# Altium schematic sheet Connectors-and-Filters.schdoc(Connectors-and-Filters)
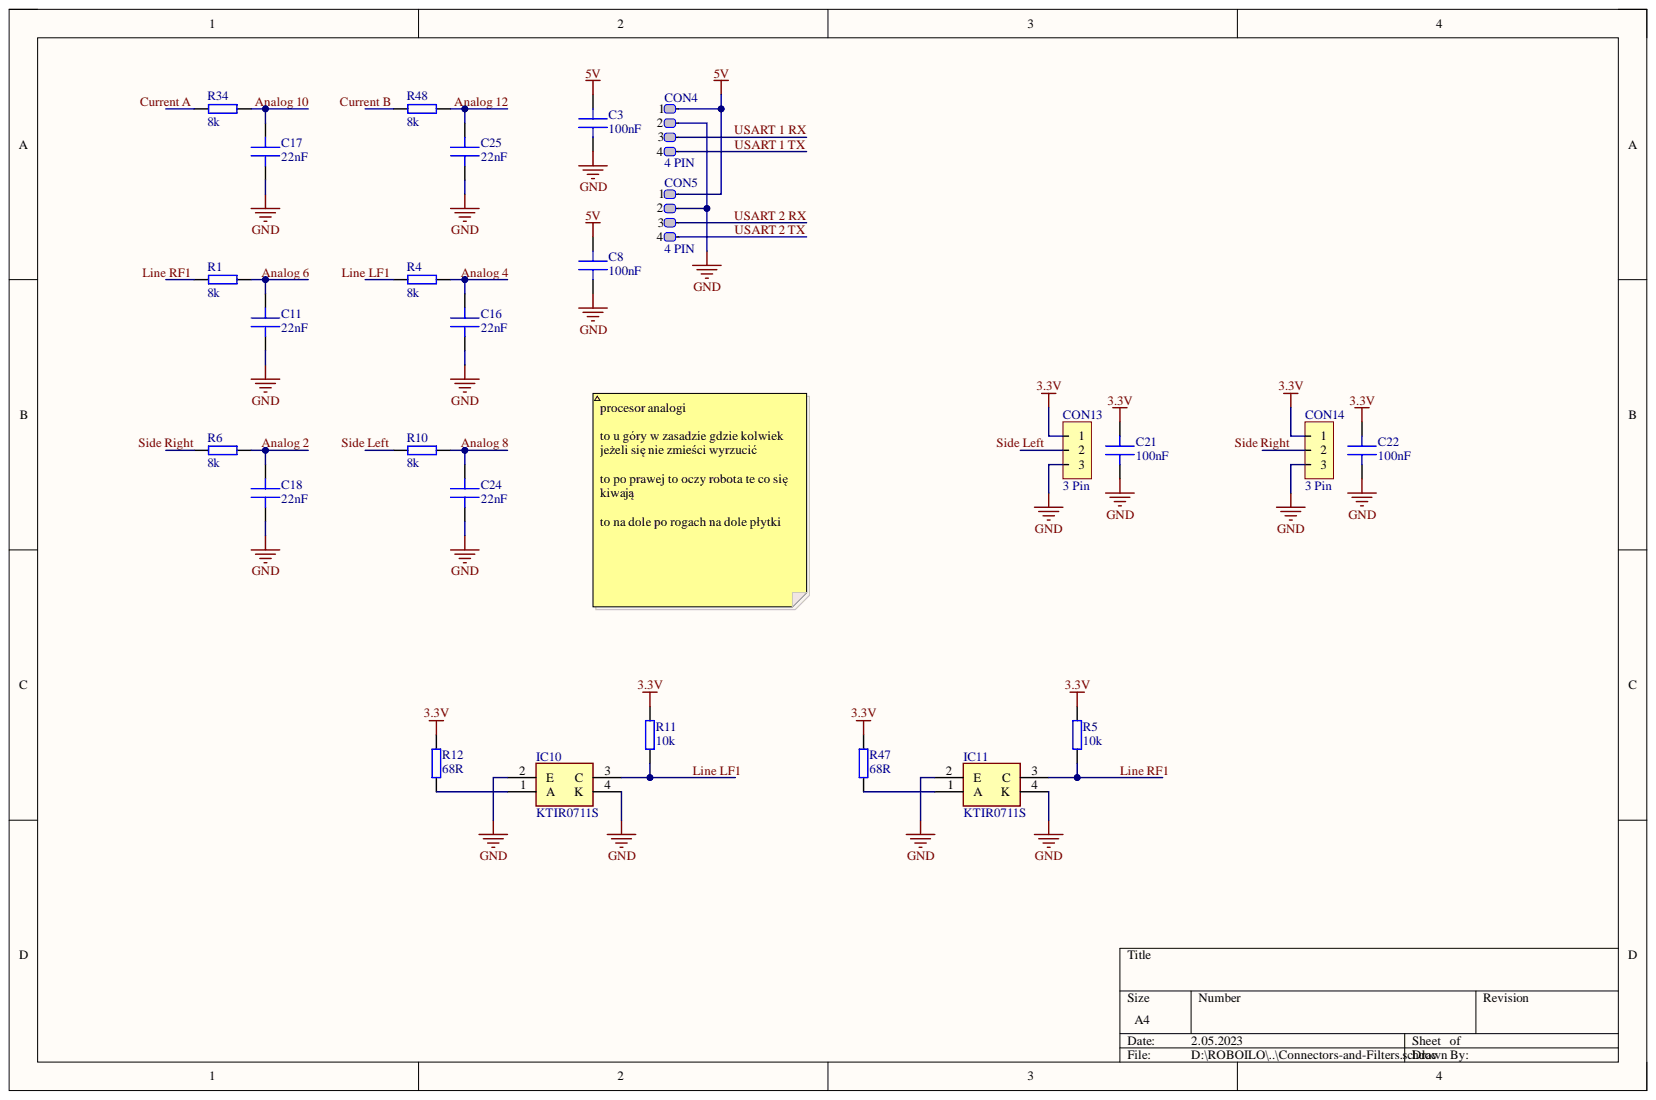
[diagram p0: Connectors-and-Filters.schdoc]

## Parts
C3  100nF  [C 0402]
C8  100nF  [C 0402]
C11  22nF  [C 0402]
C16  22nF  [C 0402]
C17  22nF  [C 0402]
C18  22nF  [C 0402]
C21  100nF  [C 0402]
C22  100nF  [C 0402]
C24  22nF  [C 0402]
C25  22nF  [C 0402]
CON4  4 PIN  [SM04B-SRSS-TB]
CON5  4 PIN  [SM04B-SRSS-TB]
CON13  3 Pin  [BM03B-SRSS-TB]
CON14  3 Pin  [BM03B-SRSS-TB]
IC10  KTIR0711S  [KTIR0711S]
IC11  KTIR0711S  [KTIR0711S]
R1  8k  [R 0402]
R4  8k  [R 0402]
R5  10k  [R 0402]
R6  8k  [R 0402]
R10  8k  [R 0402]
R11  10k  [R 0402]
R12  68R  [R 0402]
R34  8k  [R 0402]
R47  68R  [R 0402]
R48  8k  [R 0402]

## Nets
3.3V: C21.1 C22.1 CON13.1 CON14.1 R5.1 R11.1 R12.1 R47.1
5V: C3.1 C8.1 CON4.1 CON5.1
GND: C3.2 C8.2 C11.2 C16.2 C17.2 C18.2 C21.2 C22.2 C24.2 C25.2 CON4.2 CON5.2 CON13.3 CON14.3 IC10.2(E) IC10.4(K) IC11.2(E) IC11.4(K)
Analog 2: C18.1 R6.1
Analog 4: C16.1 R4.1
Analog 6: C11.1 R1.1
Analog 8: C24.1 R10.1
Analog 10: C17.1 R34.1
Analog 12: C25.1 R48.1
Current A: R34.2
Current B: R48.2
Line LF1: IC10.3(C) R4.2 R11.2
Line RF1: IC11.3(C) R1.2 R5.2
Side Left: CON13.2 R10.2
Side Right: CON14.2 R6.2
USART 1 RX: CON4.3
USART 1 TX: CON4.4
USART 2 RX: CON5.3
USART 2 TX: CON5.4
NetIC10_1: IC10.1(A) R12.2
NetIC11_1: IC11.1(A) R47.2

## Library data of the parts
C3 C8 C11 C16-C18 C21 C22 C24 C25 = Capacitor: MLCC Capacitor; Component Kind: Standard; Library Name: Components.SchLib
IC10 IC11 = KTIR0711S: Integrated Circuit; Manufacturer_Name: Kingbright; Component Kind: Standard; Datasheet Link: https://componentsearchengine.com/Datasheets/2/KTIR0711S.pdf; Height: 1.75mm; Manufacturer_Part_Number: KTIR0711S; Mouser Part Number: N/A; Mouser Price/Stock: https://www.mouser.co.uk/ProductDetail/Kingbright/KTIR0711S?qs=2JU0tDl2GZ3YXiPz2j0FpQ%3D%3D
R1 R4-R6 R10-R12 R34 R47 R48 = Resistor: General pourpouse resistor; Component Kind: Standard; Library Name: Components.SchLib
CON4 CON5 = 4 PIN: Component Kind: Standard; Library Name: Connectors.SchLib
CON13 CON14 = 3 Pin: Component Kind: Standard; Library Name: Connectors.SchLib
